# Altium schematic sheet BLE_Controller.SchDoc
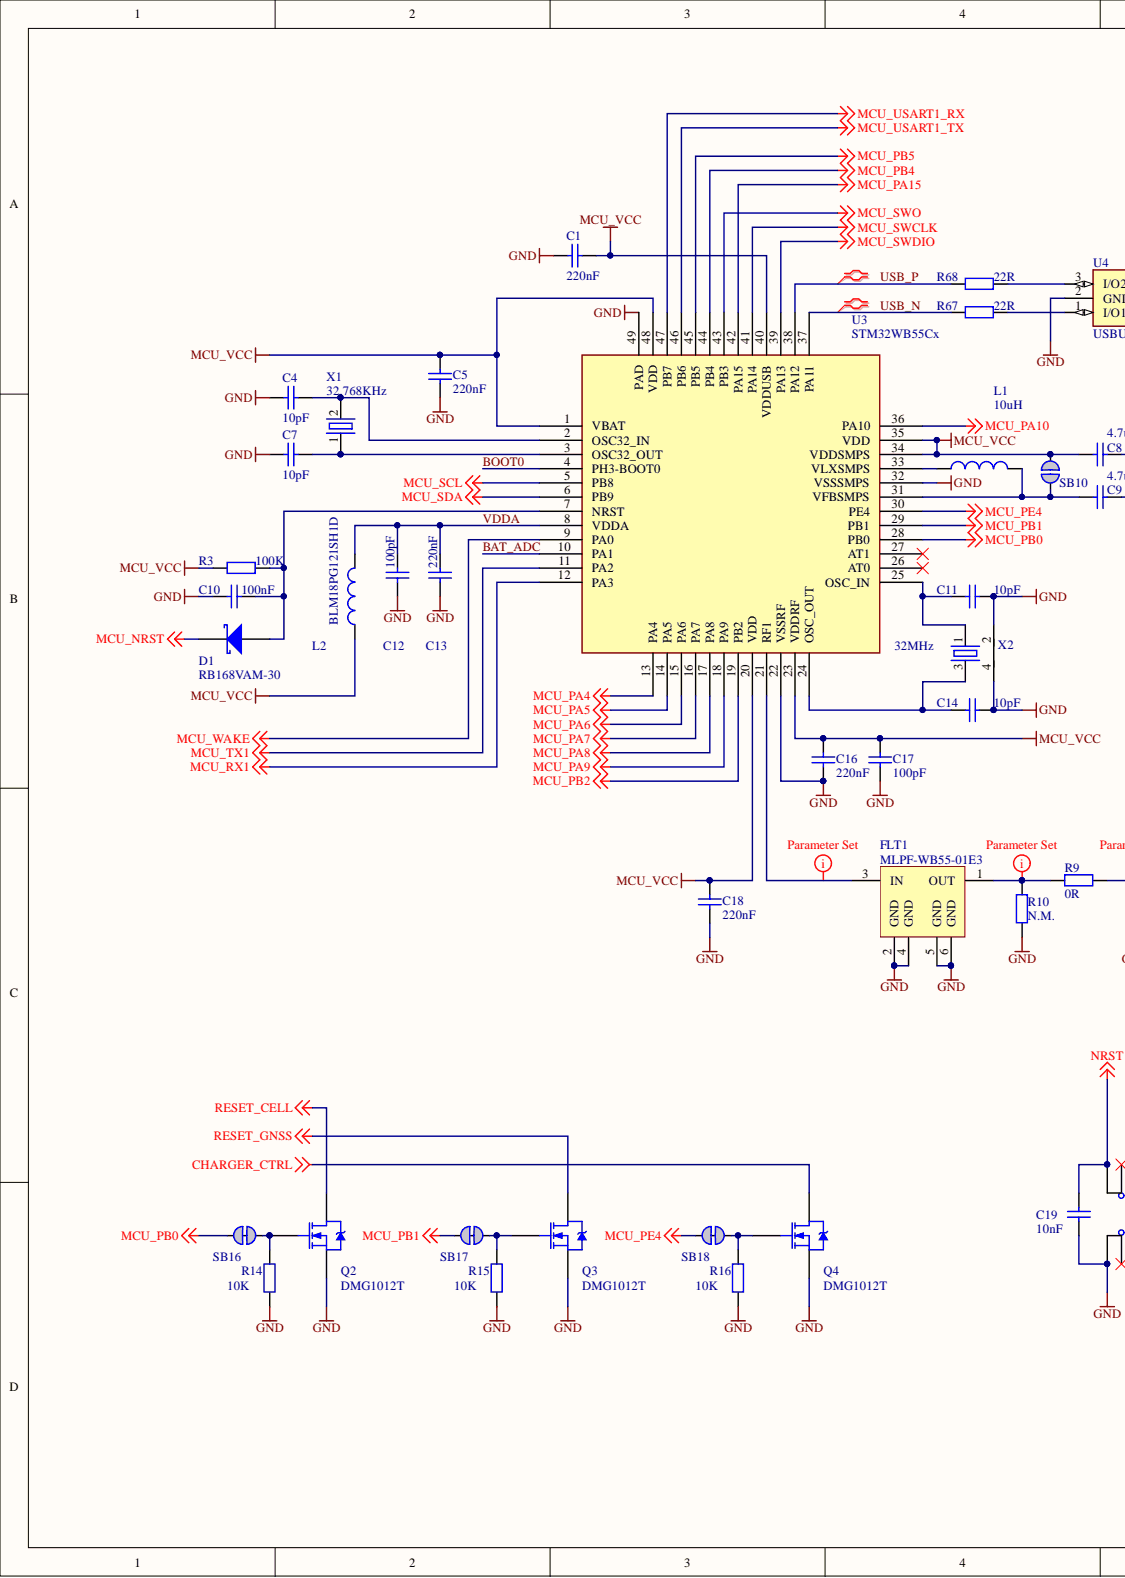
[diagram p0: BLE_Controller.SchDoc, left]
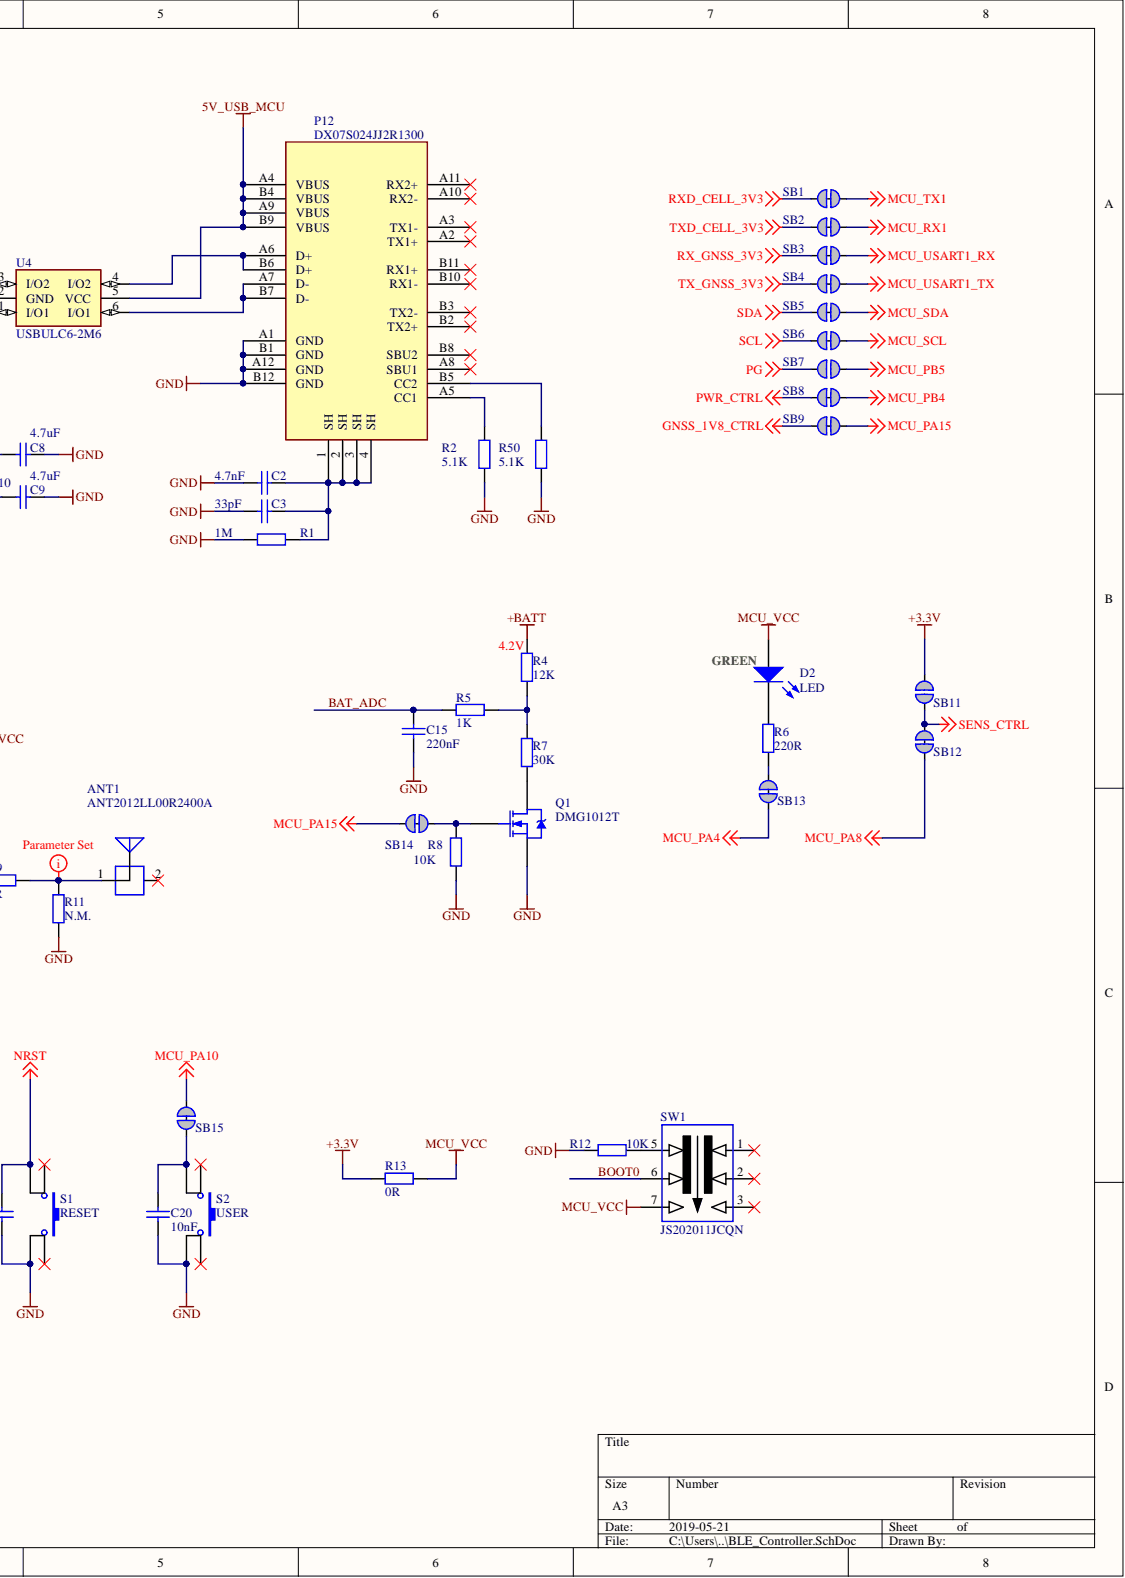
[diagram p1: BLE_Controller.SchDoc, right]

## Parts
ANT1  ANT2012LL00R2400A  [YEGEO_BT_Antena_0805]
C1  value 220nF  [C0402]  (hidden comment: Cap Semi)
C2  value 4.7nF  [C0402]  (hidden comment: Cap Semi)
C3  value 33pF  [C0402]  (hidden comment: Cap Semi)
C4  value 10pF  [C0402]  (hidden comment: Cap Semi)
C5  value 220nF  [C0402]  (hidden comment: Cap Semi)
C7  value 10pF  [C0402]  (hidden comment: Cap Semi)
C8  value 4.7uF  [C0402]  (hidden comment: Cap Semi)
C9  value 4.7uF  [C0402]  (hidden comment: Cap Semi)
C10  value 100nF  [C0402]  (hidden comment: Cap Semi)
C11  value 10pF  [C0402]  (hidden comment: Cap Semi)
C12  value 100pF  [C0402]  (hidden comment: Cap Semi)
C13  value 220nF  [C0402]  (hidden comment: Cap Semi)
C14  value 10pF  [C0402]  (hidden comment: Cap Semi)
C15  value 220nF  [C0402]  (hidden comment: Cap Semi)
C16  value 220nF  [C0402]  (hidden comment: Cap Semi)
C17  value 100pF  [C0402]  (hidden comment: Cap Semi)
C18  value 220nF  [C0402]  (hidden comment: Cap Semi)
C19  value 10nF  [C0402]  (hidden comment: Cap Semi)
C20  value 10nF  [C0402]  (hidden comment: Cap Semi)
D1  RB168VAM-30  [SOD323]
D2  LED  [PICOLED]
FLT1  MLPF-WB55-01E3  [Bumpless CSP]
L1  value 10uH  [L0805]  (hidden comment: Inductor)
L2  value BLM18PG121SH1D  Manufacturer Part No.: BLM18PG121SH1D  [L0603]  (hidden comment: Inductor)
P12  DX07S024JJ2R1300  [DX07_USB_C]
Q1  DMG1012T  [SOT-523_custom]
Q2  DMG1012T  [SOT-523_custom]
Q3  DMG1012T  [SOT-523_custom]
Q4  DMG1012T  [SOT-523_custom]
R1  value 1M  [R0402]  (hidden comment: Res2)
R2  value 5.1K  [R0402]  (hidden comment: Res2)
R3  value 100K  [R0402]  (hidden comment: Res2)
R4  value 12K  [R0402]  (hidden comment: Res2)
R5  value 1K  [R0402]  (hidden comment: Res2)
R6  value 220R  [R0402]  (hidden comment: Res2)
R7  value 30K  [R0402]  (hidden comment: Res2)
R8  value 10K  [R0402]  (hidden comment: Res2)
R9  value 0R  [R0402]  (hidden comment: Res2)
R10  value N.M.  [R0402]  (hidden comment: Res2)  (no BOM)
R11  value N.M.  [R0402]  (hidden comment: Res2)  (no BOM)
R12  value 10K  [R0402]  (hidden comment: Res2)
R13  value 0R  [R0402]  (hidden comment: Res2)
R14  value 10K  [R0402]  (hidden comment: Res2)
R15  value 10K  [R0402]  (hidden comment: Res2)
R16  value 10K  [R0402]  (hidden comment: Res2)
R50  value 5.1K  [R0402]  (hidden comment: Res2)
R67  value 22R  [R0402]  (hidden comment: Res2)
R68  value 22R  [R0402]  (hidden comment: Res2)
S1  RESET  [PTS645S_button]
S2  USER  [PTS645S_button]
SB1  Solderbridge  [Solderbridge_Shorted]  (value not drawn)
SB2  Solderbridge  [Solderbridge_Shorted]  (value not drawn)
SB3  Solderbridge  [Solderbridge_Shorted]  (value not drawn)
SB4  Solderbridge  [Solderbridge_Shorted]  (value not drawn)
SB5  Solderbridge  [Solderbridge_Shorted]  (value not drawn)
SB6  Solderbridge  [Solderbridge_Shorted]  (value not drawn)
SB7  Solderbridge  [Solderbridge]  (value not drawn)  (no BOM)
SB8  Solderbridge  [Solderbridge_Shorted]  (value not drawn)
SB9  Solderbridge  [Solderbridge_Shorted]  (value not drawn)
SB10  Solderbridge  [Solderbridge]  (value not drawn)  (no BOM)
SB11  Solderbridge  [Solderbridge]  (value not drawn)  (no BOM)
SB12  Solderbridge  [Solderbridge_Shorted]  (value not drawn)
SB13  Solderbridge  [Solderbridge_Shorted]  (value not drawn)
SB14  Solderbridge  [Solderbridge_Shorted]  (value not drawn)
SB15  Solderbridge  [Solderbridge_Shorted]  (value not drawn)
SB16  Solderbridge  [Solderbridge_Shorted]  (value not drawn)
SB17  Solderbridge  [Solderbridge_Shorted]  (value not drawn)
SB18  Solderbridge  [Solderbridge_Shorted]  (value not drawn)
SW1  JS202011JCQN  [JS202011JCQN]
U3  STM32WB55Cx  [UFQFPN48]
U4  USBULC6-2M6  [Micro_QFN_6L]
X1  32.768KHz  [XTAL_3215]
X2  32MHz  [XTAL_3.5x2.5]

## Nets
5V_USB_MCU: P12.A4(VBUS) P12.A9(VBUS) P12.B4(VBUS) P12.B9(VBUS) U4.5(VCC)
+3.3V: R13.2 SB11.1
+BATT: R4.1
CHARGER_CTRL: Q4.2
GND: C1.2 C2.1 C3.1 C4.1 C5.1 C7.2 C8.2 C9.2 C10.1 C11.2 C12.1 C13.1 C14.1 C15.2 C16.1 C17.1 C18.1 C19.1 C20.2 FLT1.2(GND) FLT1.4(GND) FLT1.5(GND) FLT1.6(GND) P12.A1(GND) P12.A12(GND) P12.B1(GND) P12.B12(GND) Q1.3 Q2.3 Q3.3 Q4.3 R1.1 R2.1 R8.1 R10.2 R11.2 R12.1 R14.1 R15.1 R16.1 R50.1 S1.4 S2.4 U3.22(VSSRF) U3.32(VSSSMPS) U3.49(PAD) U4.2(GND) X2.2 X2.4
GNSS_1V8_CTRL: SB9.2
MCU_NRST: D1.2
MCU_PA4: SB13.2 U3.13(PA4)
MCU_PA5: U3.14(PA5)
MCU_PA6: U3.15(PA6)
MCU_PA7: U3.16(PA7)
MCU_PA8: SB12.1 U3.17(PA8)
MCU_PA9: U3.18(PA9)
MCU_PA10: SB15.1 U3.36(PA10)
MCU_PA15: SB9.1 SB14.2 U3.42(PA15)
MCU_PB0: SB16.2 U3.28(PB0)
MCU_PB1: SB17.2 U3.29(PB1)
MCU_PB2: U3.19(PB2)
MCU_PB4: SB8.1 U3.44(PB4)
MCU_PB5: SB7.2 U3.45(PB5)
MCU_PE4: SB18.2 U3.30(PE4)
MCU_RX1: SB2.1 U3.12(PA3)
MCU_SCL: SB6.1 U3.5(PB8)
MCU_SDA: SB5.1 U3.6(PB9)
MCU_SWCLK: U3.41(PA14)
MCU_SWDIO: U3.39(PA13)
MCU_SWO: U3.43(PB3)
MCU_TX1: SB1.1 U3.11(PA2)
MCU_USART1_RX: SB3.1 U3.47(PB7)
MCU_USART1_TX: SB4.1 U3.46(PB6)
MCU_VCC: C1.1 C5.2 C8.1 C16.2 C17.2 C18.2 D2.1 L2.1 R3.1 R13.1 SB10.2 SW1.7 U3.1(VBAT) U3.20(VDD) U3.23(VDDRF) U3.34(VDDSMPS) U3.35(VDD) U3.40(VDDUSB) U3.48(VDD)
MCU_WAKE: U3.9(PA0)
NRST: C19.2 S1.2
PG: SB7.1
PWR_CTRL: SB8.2
RESET_CELL: Q2.2
RESET_GNSS: Q3.2
RX_GNSS_3V3: SB3.2
RXD_CELL_3V3: SB1.2
SCL: SB6.2
SDA: SB5.2
SENS_CTRL: SB11.2 SB12.2
TX_GNSS_3V3: SB4.2
TXD_CELL_3V3: SB2.2
BAT_ADC: C15.1 R5.1 U3.10(PA1)
BOOT0: SW1.6 U3.4(PH3-BOOT0)
USB_N: R67.2 U3.37(PA11)
USB_P: R68.2 U3.38(PA12)
VDDA: C12.2 C13.2 L2.2 U3.8(VDDA)
NetANT1_1: ANT1.1 R9.1 R11.1
NetC2_2: C2.2 C3.2 P12.1(SH) P12.2(SH) P12.3(SH) P12.4(SH) R1.2
NetC4_2: C4.2 U3.2(OSC32_IN) X1.2
NetC7_1: C7.1 U3.3(OSC32_OUT) X1.1
NetC9_1: C9.1 L1.2 SB10.1 U3.31(VFBSMPS)
NetC10_2: C10.2 D1.1 R3.2 U3.7(NRST)
NetC11_1: C11.1 U3.25(OSC_IN) X2.1
NetC14_2: C14.2 U3.24(OSC_OUT) X2.3
NetC20_1: C20.1 S2.2 SB15.2
NetD2_2: D2.2 R6.1
NetFLT1_1: FLT1.1(OUT) R9.2 R10.1
NetFLT1_3: FLT1.3(IN) U3.21(RF1)
NetL1_1: L1.1 U3.33(VLXSMPS)
NetP12_A5: P12.A5(CC1) R2.2
NetP12_A6: P12.A6(D+) P12.B6(D+) U4.4(I/O2)
NetP12_A7: P12.A7(D-) P12.B7(D-) U4.6(I/O1)
NetP12_B5: P12.B5(CC2) R50.2
NetQ1_1: Q1.1 R8.2 SB14.1
NetQ1_2: Q1.2 R7.2
NetQ2_1: Q2.1 R14.2 SB16.1
NetQ3_1: Q3.1 R15.2 SB17.1
NetQ4_1: Q4.1 R16.2 SB18.1
NetR4_2: R4.2 R5.2 R7.1
NetR6_2: R6.2 SB13.1
NetR12_2: R12.2 SW1.5
NetR67_1: R67.1 U4.1(I/O1)
NetR68_1: R68.1 U4.3(I/O2)

Not connected: ANT1 2; P12 A2(TX1+) A3(TX1-) A8(SBU1) A10(RX2-) A11(RX2+) B2(TX2+) B3(TX2-) B8(SBU2) B10(RX1-) B11(RX1+); S1 1 3; S2 1 3; SW1 1 2 3; U3 26(AT0) 27(AT1)

## Library data of the parts
ANT1 = Antenna: Generic Antenna; Manufacturer: YAGEO; Part Description: Ceramic Chip Antenna; Specification: 2.4 – 2.5 GHZ; Component Kind: Standard; Link: https://www.rutronik24.com/product/yageo/ant2012ll00r2400a/42235.html; Manufacturer Part No.: ANT2012LL00R2400A; Supplier: Rutronik; Supplier part number: WIREAC1413; Value: ANT2012LL00R2400A; Library Name: RUT_GE310_GNSS.SCHLIB
C1-C5 C7-C14 C16-C18 = Cap Semi: Capacitor (Semiconductor SIM Model); Manufacturer: YAGEO; Manufacturer Part No.: CC0402JRNPO9BN100; Part Description: Ceramic Capacitor; Specification: NP0, 6.3V, 5%; Component Kind: Standard; Library Name: Miscellaneous Devices.IntLib; Link: https://www.rutronik24.com/product/yageo/cc0402jrnpo9bn100/29735.html; Signal Integrity: Cap Semi; Simulation: CAP; Supplier: Rutronik; Supplier part number: KKK14556
C15 = Cap Semi: Capacitor (Semiconductor SIM Model); Manufacturer: YAGEO; Manufacturer Part No.: CC0402KRX5R5BB224; Part Description: Ceramic Capacitor; Specification: X5R, 6.3V, 10%; Component Kind: Standard; Library Name: Miscellaneous Devices.IntLib; Link: https://www.rutronik24.com/product/yageo/cc0402krx5r5bb224/3611951.html; Signal Integrity: Cap Semi; Simulation: CAP; Supplier: Rutronik; Supplier part number: KKK23148
C19 C20 = Cap Semi: Capacitor (Semiconductor SIM Model); Manufacturer: Samsung Electronics; Manufacturer Part No.: CL05B103KB5NNNC; Part Description: Ceramic Capacitor; Specification: X5R, 6.3V, 10%; Component Kind: Standard; Library Name: Miscellaneous Devices.IntLib; Link: https://www.rutronik24.com/product/samsung+em/cl05b103kb5nnnc/6075254.html; Signal Integrity: Cap Semi; Simulation: CAP; Supplier: Rutronik; Supplier part number: KKK21418
D1 = D Schottky: Schottky Diode; Manufacturer: ROHM; Part Description: Low VF Schottky Barrier Rectifier; Specification: 30V, 1A; Component Kind: Standard; Library Name: Miscellaneous Devices.IntLib; Link: http://rohmfs.rohm.com/en/products/databook/datasheet/discrete/diode/schottky_barrier/rb168vam-30tr-e.pdf; Manufacturer Part No.: RB168VAM-30; Signal Integrity: D Schottky; Simulation: SKYDIODE; Supplier: Rutronik; Supplier part number: RB168VAM-30; Value: RB168VAM-30
D2 = LED2: Typical SMD LED; Manufacturer: ROHM; Manufacturer Part No.: SML-P13PTT86R; Specification: Green, 20mA; Value: SML-P13PTT86R; Component Kind: Standard; Library Name: Miscellaneous Devices.IntLib; Link: https://www.rutronik24.com/product/rohm/sml-p13ptt86r/11064312.html; Part Description: Typical SMD LED; Simulation: LED2; Supplier: Rutronik; Supplier part number: LED26047
FLT1 = MLPF-WB55-01E3: 2.4 GHz low pass filter matched to STM32WB55Cx/Rx; Manufacturer: STMicroelectronics; Specification: 2.4GHz, CSP; Component Kind: Standard; Library Name: MLPF-WB55-01E3.SchLib; Link: https://www.digikey.com/product-detail/en/stmicroelectronics/MLPF-WB55-01E3/497-19088-1-ND/10057128; Manufacturer Part No.: MLPF-WB55-01E3; Part Description: 2.4 GHz low pass filter; Supplier: Digi-Key; Supplier part number: 497-19088-1-ND; Value: MLPF-WB55-01E3
L1 = Inductor: Manufacturer: muRata; Manufacturer Part No.: LQM21FN100M70L; Specification: 100mA; Component Kind: Standard; Description: Inductor; Library Name: Miscellaneous Devices.IntLib; Link: https://www.rutronik24.com/product/murata/lqm21fn100m70l/25672.html; Part Description: Inductor; Signal Integrity: Inductor; Simulation: INDUCTOR; Supplier: Rutronik; Supplier part number: IND8474
L2 = Inductor: Manufacturer: muRata; Part Description: Ferrite Bead; Specification: 120R, 2A; Component Kind: Standard; Description: Inductor; Library Name: Miscellaneous Devices.IntLib; Link: https://www.rutronik24.com/product/murata/blm18pg121sh1d/5885588.html; Signal Integrity: Inductor; Simulation: INDUCTOR; Supplier: Rutronik; Supplier part number: FE3867
P12 = DX07S024JJ2R1300: 24P USB C DUAL SMT ON BOARD; Manufacturer: JAE; Specification: Type C; Component Kind: Standard; Library Name: DX07S024JJ2R1300.SchLib; Link: https://www.rutronik24.com/product/jae/dx07s024jj2r1300/8301548.html; Manufacturer Part No.: DX07S024JJ2R1300; Part Description: 24P USB C DUAL SMT ON BOARD; Supplier: Rutronik; Supplier part number: CONIO1808; Value: DX07S024JJ2R1300
Q1-Q4 = NMOS-2: N-Channel Power MOSFET; Manufacturer: Diodes Inc.; Manufacturer Part No.: DMG1012T-7; Part Description: N-Channel MOSFET; Specification: 20V, 0.63A; Value: DMG1012T-7; Component Kind: Standard; Library Name: Miscellaneous Devices.IntLib; Link: https://www.rutronik24.com/product/diodes+inc/dmg1012t-7/5168665.html; Simulation: IRF1010; Supplier: Rutronik; Supplier part number: TMOSS6623
R1 R9-R11 R13 = Res2: Manufacturer: YAGEO; Manufacturer Part No.: RC0402FR-070RL; Part Description: Thick Film Resistor; Specification: 5% 0,063W; Library Name: Miscellaneous Devices.IntLib; Link: https://www.rutronik24.com/product/yageo/rc0402fr-070rl/5183530.html; Signal Integrity: Res; Simulation: RESISTOR; Supplier: Rutronik; Supplier part number: WRC53079
  R1 R9 R13: Component Kind: Standard
R2 R3 R8 R12 R14-R16 R50 R67 R68 = Res2: Manufacturer: ASJ; Manufacturer Part No.: CR10-103-JK; Part Description: Thick Film Resistor; Specification: 5% 0,063W; Component Kind: Standard; Library Name: Miscellaneous Devices.IntLib; Link: https://www.rutronik24.com/product/asj/cr10-103-jk/54295.html; Signal Integrity: Res; Simulation: RESISTOR; Supplier: Rutronik; Supplier part number: WRC40976
R4 = Res2: Manufacturer: ASJ; Manufacturer Part No.: CR10-105-JK; Part Description: Thick Film Resistor; Specification: 5% 0,063W; Component Kind: Standard; Library Name: Miscellaneous Devices.IntLib; Link: https://www.rutronik24.com/product/asj/cr10-105-jk/51971.html; Signal Integrity: Res; Simulation: RESISTOR; Supplier: Rutronik; Supplier part number: WRC35254
R5 = Res2: Manufacturer: ASJ; Manufacturer Part No.: CR10-102-JK; Part Description: Thick Film Resistor; Specification: 5% 0,063W; Component Kind: Standard; Library Name: Miscellaneous Devices.IntLib; Link: https://www.rutronik24.com/product/asj/cr10-102-jk/54392.html; Signal Integrity: Res; Simulation: RESISTOR; Supplier: Rutronik; Supplier part number: WRC41426
R6 = Res2: Manufacturer: ASJ; Manufacturer Part No.: CR10-221-JK; Part Description: Thick Film Resistor; Specification: 5% 0,063W; Component Kind: Standard; Library Name: Miscellaneous Devices.IntLib; Link: https://www.rutronik24.com/product/asj/cr10-221-jk/51883.html; Signal Integrity: Res; Simulation: RESISTOR; Supplier: Rutronik; Supplier part number: WRC35108
R7 = Res2: Manufacturer: YAGEO; Manufacturer Part No.: RC0402JR-07390KL; Part Description: Thick Film Resistor; Specification: 5% 0,063W; Component Kind: Standard; Library Name: Miscellaneous Devices.IntLib; Link: https://www.rutronik24.com/product/yageo/rc0402jr-07390kl/51055.html; Signal Integrity: Res; Simulation: RESISTOR; Supplier: Rutronik; Supplier part number: WRC33888
S1 S2 = SW-PB: Switch; Manufacturer: C&K; Manufacturer Part No.: PTS645SM43SMTR92 LFS; Part Description: Tactile Button; Specification: 1.6N, Straight, SMT; Value: PTS645SM43SMTR92 LFS; Component Kind: Standard; Link: https://www.rutronik24.com/product/c%26k/pts645sm43smtr92+lfs/3580965.html; Supplier: Rutronik; Supplier part number: SWITCH8818; Library Name: RUT_L865_Project_1.SCHLIB
SB1-SB6 SB8 SB9 SB12-SB18 = Solderbridge Shorted: Component Kind: Net Tie; Value: Solderbridge
SW1 = JS202011JCQN: Sub-Miniature Slide Switch, VERTICAL MOUNT SMT - J BEND; Manufacturer: CK Switches; Specification: 6V DC, 0.3A; Component Kind: Standard; Library Name: JS202011JCQN.SchLib; Link: https://www.ckswitches.com/media/1422/js.pdf; Manufacturer Part No.: JS202011JCQN; Part Description: VERTICAL MOUNT SMT - J BEND; Supplier: Rutronik; Supplier part number: SWITCH10809; Value: JS202011JCQN
U3 = STM32WBxx_QFN48: Multiprotocol wireless 32-bit MCU Arm®-based Cortex®-M4  with FPU, Bluetooth® 5 and 802.15.4 radio solution; Manufacturer: STMicroelectronics; Manufacturer Part No.: STM32WB55CGU6; Specification: 1MB Flash, 256K BRAM; Value: STM32WB55CGU6; Component Kind: Standard; Link: https://www.st.com/en/microcontrollers-microprocessors/stm32wb55cg.html; Part Description: Multiprotocol wireless 32-bit MCU Arm®-based Cortex®-M4  with FPU, Bluetooth® 5 and 802.15.4 radio solution; Supplier: Rutronik; Supplier part number: STM32WB55CGU6; Library Name: RUT2CLOUDPrjPcb.SCHLIB
U4 = USBULC6-2M6: Ultra large bandwidth ESD protection; Manufacturer: STMicroelectronics; Specification: 6V, 0.6pF; Component Kind: Standard; Library Name: USBULC6-2M6.SchLib; Link: https://www.rutronik24.com/product/st/usbulc6-2m6/3623499.html; Manufacturer Part No.: USBULC6-2M6; Part Description: Ultra large bandwidth ESD protection; Supplier: Rutronik; Supplier part number: DTRL11259; Value: USBULC6-2M6
X1 = XTL-000011-01: Manufacturer: Epson Electronics; Manufacturer Part No.: FC-135 32.7680KA-AC3; Part Description: XTAL 3.2x1.5mm; Specification: 32.768 kHz, 9pF, 20ppm; Value: FC-135 32.7680KA-AC3; Component Kind: Standard; Link: https://www.rutronik24.com/product/epson/fc-135r+32%2C768khz++9pf++20ppm+++rohsconf/5825395.html; Supplier: Rutronik; Supplier part number: QUARZ6279; Library Name: RUT_L865_Project_1.SCHLIB
X2 = XTL-000011-01: Manufacturer: Kyocera; Manufacturer Part No.: CX3225SB32000D0FLJCC; Part Description: XTAL 3.2x2.5mm; Specification: 32MHz, 8pF, 15ppm; Value: CX3225SB32000D0FLJCC; Component Kind: Standard; Link: https://global.kyocera.com/prdct/electro/product/crystal-device/xtal/; Supplier: Rutronik; Supplier part number: CX3225SB32000D0FLJCC; Library Name: RUT_L865_Project_1.SCHLIB
SB7 SB10 SB11 = Solderbridge: Value: Solderbridge
